# Altium schematic sheet MA-Power.SchDoc
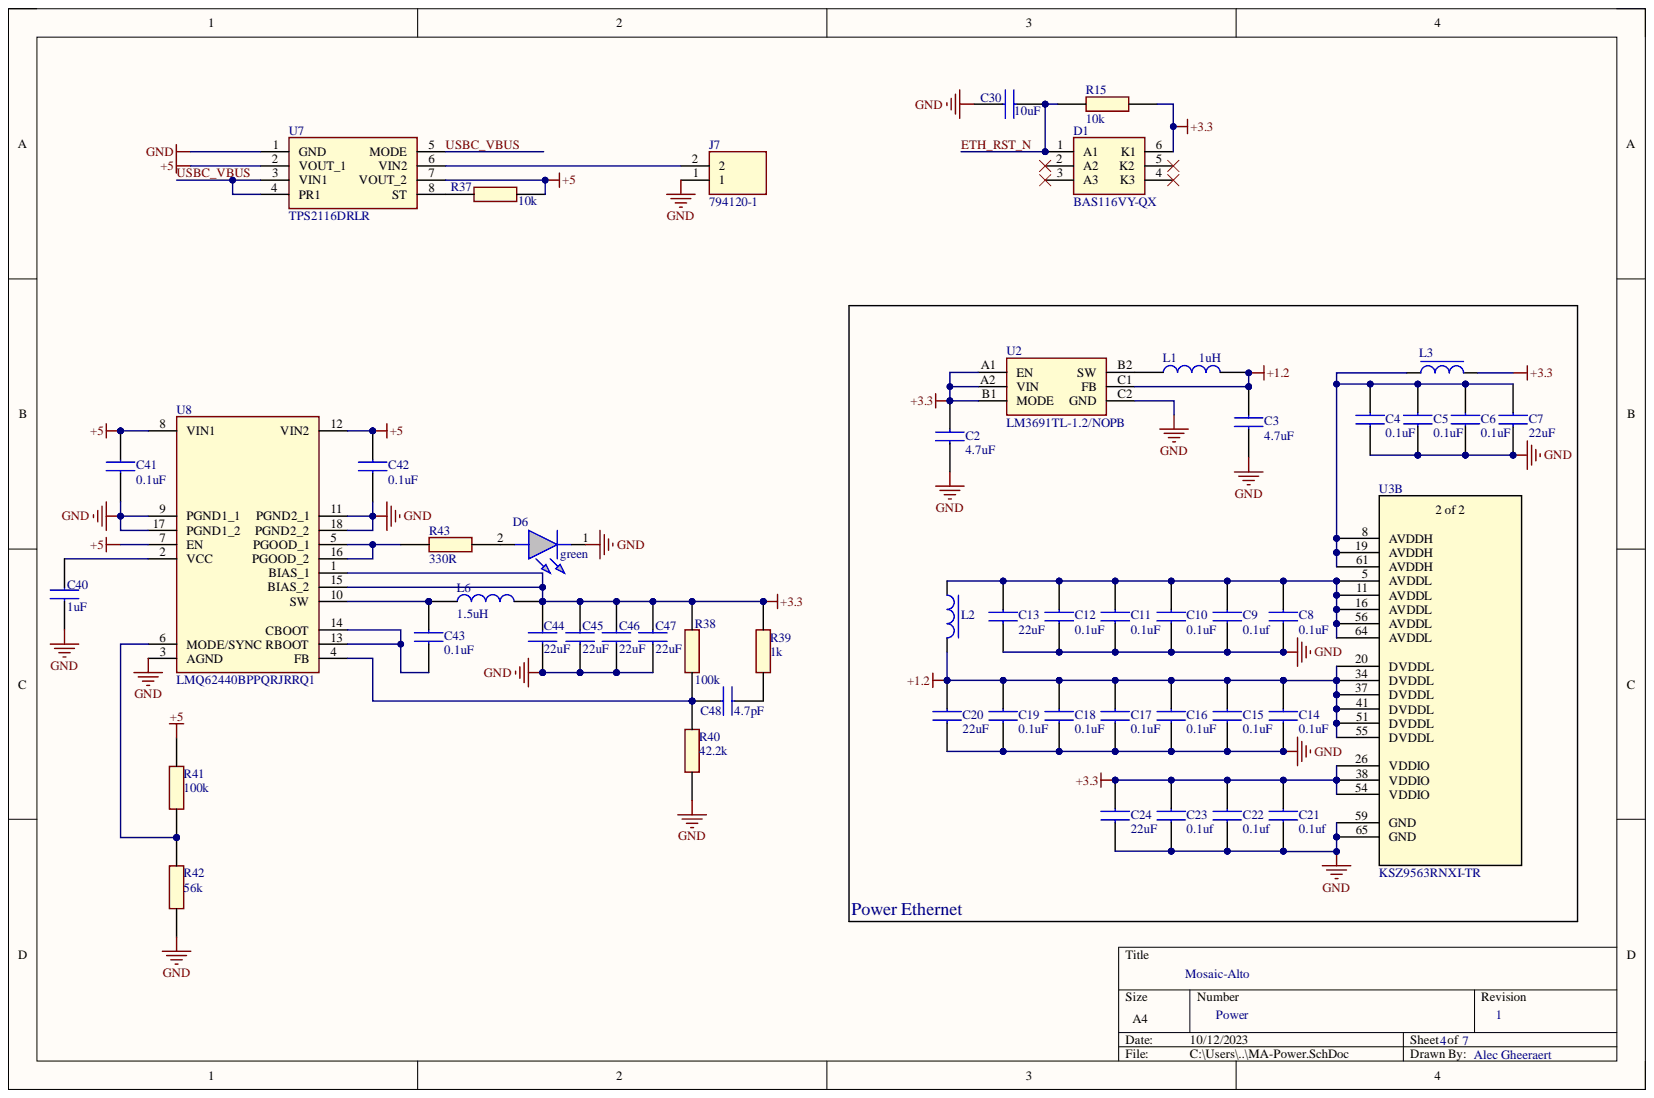
[diagram p0: MA-Power.SchDoc]

## Parts
C2  4.7uF  [CAPC1608X100N]
C3  4.7uF  [CAPC1608X100N]
C4  0.1uF  [CAPC1005X55N]
C5  0.1uF  [CAPC1005X55N]
C6  0.1uF  [CAPC1005X55N]
C7  22uF  [CAPC2012X145N]
C8  0.1uF  [CAPC1005X55N]
C9  0.1uf  [CAPC1005X55N]
C10  0.1uF  [CAPC1005X55N]
C11  0.1uF  [CAPC1005X55N]
C12  0.1uF  [CAPC1005X55N]
C13  22uF  [CAPC2012X145N]
C14  0.1uF  [CAPC1005X55N]
C15  0.1uF  [CAPC1005X55N]
C16  0.1uF  [CAPC1005X55N]
C17  0.1uF  [CAPC1005X55N]
C18  0.1uF  [CAPC1005X55N]
C19  0.1uF  [CAPC1005X55N]
C20  22uF  [CAPC2012X145N]
C21  0.1uf  [CAPC1005X55N]
C22  0.1uf  [CAPC1005X55N]
C23  0.1uf  [CAPC1005X55N]
C24  22uF  [CAPC2012X145N]
C30  10uF  [CAPC1608X100N]
C40  1uF  [CAPC1608X100N]
C41  0.1uF  [CAPC2012X145N]
C42  0.1uF  [CAPC2012X145N]
C43  0.1uF  [CAPC1005X55N]
C44  22uF  [CAPC2012X145N]
C45  22uF  [CAPC2012X145N]
C46  22uF  [CAPC2012X145N]
C47  22uF  [CAPC2012X145N]
C48  4.7pF  [CAPC1608X87N]
D1  BAS116VY-QX  [BAS116VYQX]
D6  green  [APT1608ZGCAMT]
J7  794120-1  [7941201]
L1  1uH  [INDC2016X100N]
L2  MPZ1608S121ATDH5  [BEADC1608X75N]  (value not drawn)
L3  MPZ1608S121ATDH5  [BEADC1608X75N]  (value not drawn)
L6  1.5uH  [INDC1005X55N]
R15  10k  [RESC1005X40N]
R37  10k  [RESC1005X40N]
R38  100k  [RESC1005X40N]
R39  1k  [RESC1005X40N]
R40  42.2k  [RESC1005X40N]
R41  100k  [RESC1005X40N]
R42  56k  [RESC1005X40N]
R43  330R  [RESC1005X40N]
U2  LM3691TL-1.2/NOPB  [BGA6C50P2X3_126X156X68]
U3  KSZ9563RNXI-TR  [VQFN64_NX_MCH]  (part B)
U7  TPS2116DRLR  [SOTFL50P160X60-8N]
U8  LMQ62440BPPQRJRRQ1  [LMQ62440BPPQRJRRQ1]

## Nets
+1.2: C3.1 C14.1 C15.1 C16.1 C17.1 C18.1 C19.1 C20.1 L1.2 L2.2 U2.C1(FB) U3.20(DVDDL) U3.34(DVDDL) U3.37(DVDDL) U3.41(DVDDL) U3.51(DVDDL) U3.55(DVDDL)
+3.3: C2.1 C21.1 C22.1 C23.1 C24.1 C44.1 C45.1 C46.1 C47.1 D1.6(K1) L3.2 L6.2 R15.1 R38.1 R39.2 U2.A1(EN) U2.A2(VIN) U2.B1(MODE) U3.26(VDDIO) U3.38(VDDIO) U3.54(VDDIO) U8.1(BIAS_1) U8.15(BIAS_2)
+5: C41.1 C42.1 R37.2 R41.2 U7.2(VOUT_1) U7.7(VOUT_2) U8.7(EN) U8.8(VIN1) U8.12(VIN2)
GND: C2.2 C3.2 C4.2 C5.2 C6.2 C7.2 C8.2 C9.2 C10.2 C11.2 C12.2 C13.2 C14.2 C15.2 C16.2 C17.2 C18.2 C19.2 C20.2 C21.2 C22.2 C23.2 C24.2 C30.2 C40.2 C41.2 C42.2 C44.2 C45.2 C46.2 C47.2 D6.1 J7.1 R40.2 R42.2 U2.C2(GND) U3.59(GND) U3.65(GND) U7.1(GND) U8.3(AGND) U8.9(PGND1_1) U8.11(PGND2_1) U8.17(PGND1_2) U8.18(PGND2_2)
ETH_RST_N: C30.1 D1.1(A1) R15.2
USBC_VBUS: U7.3(VIN1) U7.4(PR1) U7.5(MODE)
NetC4_1: C4.1 C5.1 C6.1 C7.1 L3.1 U3.8(AVDDH) U3.19(AVDDH) U3.61(AVDDH)
NetC8_1: C8.1 C9.1 C10.1 C11.1 C12.1 C13.1 L2.1 U3.5(AVDDL) U3.11(AVDDL) U3.16(AVDDL) U3.56(AVDDL) U3.64(AVDDL)
NetC40_1: C40.1 U8.2(VCC)
NetC43_1: C43.1 L6.1 U8.10(SW)
NetC43_2: C43.2 U8.13(RBOOT) U8.14(CBOOT)
NetC48_1: C48.1 R38.2 R40.1 U8.4(FB)
NetC48_2: C48.2 R39.1
NetD6_2: D6.2 R43.2
NetJ7_2: J7.2 U7.6(VIN2)
NetL1_1: L1.1 U2.B2(SW)
NetR37_1: R37.1 U7.8(ST)
NetR41_1: R41.1 R42.1 U8.6(MODE/SYNC)
NetR43_1: R43.1 U8.5(PGOOD_1) U8.16(PGOOD_2)

Not connected: D1 2(A2) 3(A3) 4(K3) 5(K2)

## Library data of the parts
C2 C3 = CMP-00054-2: 0603 4.7µF; Manufacturer: Walsin; Dielectric: X5R; Tolerance: 20%; Voltage Rating: 6.3V; Capacitance: 4.7µF; Component Kind: Standard; Max Operating Temperature: 85; Min Operating Temperature: -55; Package: 0603; Library Name: Ghrt
C4-C6 C8-C12 C14-C19 C21-C23 C43 = CMP-00051-3: 0402I 0.1µF; Manufacturer: Walsin; Capacitance: 100nF; Dielectric: X7R; Tolerance: 10%; Voltage Rating: 10V; Component Kind: Standard; Max Operating Temperature: 125; Min Operating Temperature: -55; Package: 0402; Library Name: Ghrt
C7 C13 C20 C24 C44-C47 = CMP-00053-2: 0805 22µF; Manufacturer: Walsin; Dielectric: X5R; Tolerance: 10%; Voltage Rating: 6.3V; Capacitance: 22µF; Component Kind: Standard; Max Operating Temperature: -55; Min Operating Temperature: 85; Package: 0805; Library Name: Ghrt
C30 = CMP-00062-1: 0603 10µF; Manufacturer: Walsin; Dielectric: X5R; Tolerance: 10%; Voltage Rating: 6.3V; Capacitance: 10µF; Component Kind: Standard; Max Operating Temperature: 85; Min Operating Temperature: -55; Package: 0603; Library Name: Ghrt
C40 = CMP-00064-1: 0603 1µF; Manufacturer: Walsin; Dielectric: X5R; Tolerance: 10%; Voltage Rating: 6.3V; Capacitance: 1µF; Component Kind: Standard; Max Operating Temperature: 85; Min Operating Temperature: -55; Package: 0603; Library Name: Ghrt
C41 C42 = CMP-00059-1: 0805I 0.1µF; Manufacturer: Walsin; Capacitance: 100nF; Dielectric: X7R; Qualification: AEC-Q200; Tolerance: 10%; Voltage Rating: 50V; Component Kind: Standard; Max Operating Temperature: -55; Min Operating Temperature: 125; Package: 0805; Library Name: Ghrt
C48 = CMP-00085-1: 0603 4.7pF; Manufacturer: Walsin; Dielectric: C0G; Tolerance: 5%; Voltage Rating: 16V; Capacitance: 4.7pF; Component Kind: Standard; Max Operating Temperature: 125; Min Operating Temperature: -55; Package: 0603; Library Name: Ghrt
D1 = CMP-00061-3: Triple Diode Array; Manufacturer: Nexperia; Forward Current: 180mA; Forward Voltage: 1.25V; Max Surge Current: 10A; Peak Reverse Voltage: 85V; Reverse Current: 5nA; Component Kind: Standard; Configuration: Triple; Package: TSSOP-6; Library Name: Ghrt
D6 = CMP-00079-2: 0603 Green LED; Colour: Green; Component Kind: Standard; Library Name: Ghrt
J7 = CMP-00082-2: Right Angle PCB Header; Component Kind: Standard; Library Name: Ghrt
L1 = CMP-00048-2: 1µH Inductor; Manufacturer: TDK; Max Current: 1.3A; Max Resistance: 90; Shielding: Yes; Tolerance: 20%; Component Kind: Standard; Inductance: 1µH; Max Operating Temperature: 125; Min Operating Temperature: -40; Package: 0806; Library Name: Ghrt
L2 L3 = CMP-00050-4: 0603I 120ohms Ferrite Bead; Manufacturer: TDK; Current Rating: 2A; Qualification: AEC-Q200; Resistance Rating: 120; Tolerance: 25%; Component Kind: Standard; Max Operating Temperature: 125; Min Operating Temperature: -55; Package: 0603; Library Name: Ghrt
L6 = CMP-00083-2: 1.5uH Inductor; Component Kind: Standard; Library Name: Ghrt
R15 R37 = CMP-00026-5: 0402I 10k; Manufacturer: Walsin; Power Rating: 62.5mW; Qualification: AEC-Q200; Tolerance: 1%; Voltage Rating: 50V; Component Kind: Standard; Max Operating Temperature: 155; Min Operating Temperature: -55; Package: 0402; Resistance: 10k; Library Name: Ghrt
R38 R41 = CMP-00029-4: 0402I 100k; Manufacturer: Walsin; Power Rating: 62.5mW; Qualification: AEC-Q200; Tolerance: 1%; Voltage Rating: 50V; Component Kind: Standard; Max Operating Temperature: 155; Min Operating Temperature: -55; Package: 0402; Resistance: 100k; Library Name: Ghrt
R39 = CMP-00032-3: 0402I 1k; Manufacturer: Walsin; Power Rating: 62.5mW; Qualification: AEC-Q200; Tolerance: 1%; Voltage Rating: 50V; Component Kind: Standard; Max Operating Temperature: 155; Min Operating Temperature: -55; Package: 0402; Resistance: 1k; Library Name: Ghrt
R40 = CMP-00084-1: 0402I 42.2k; Manufacturer: Walsin; Power Rating: 62.5mW; Qualification: AEC-Q200; Tolerance: 1%; Voltage Rating: 50V; Component Kind: Standard; Max Operating Temperature: 155; Min Operating Temperature: -55; Package: 0402; Resistance: 42.2k; Library Name: Ghrt
R42 = CMP-00075-1: 0402I 56k; Manufacturer: Walsin; Power Rating: 62.5mW; Qualification: AEC-Q200; Tolerance: 1%; Voltage Rating: 50V; Component Kind: Standard; Max Operating Temperature: 155; Min Operating Temperature: -55; Package: 0402; Resistance: 56k; Library Name: Ghrt
R43 = CMP-00028-4: 0402I 330; Manufacturer: Walsin; Power Rating: 62.5mW; Qualification: AEC-Q200; Resistance: 330; Tolerance: 1%; Voltage Rating: 50V; Component Kind: Standard; Max Operating Temperature: 155; Min Operating Temperature: -55; Package: 0402; Library Name: Ghrt
U2 = CMP-00047-3: 1.2V 1A Buck Converter; Manufacturer: Texas Instruments; Channels: 1; Frequency: 4MHz; Max Input Voltage: 5.5V; Min Input Voltage: 2.3V; Component Kind: Standard; Max Operating Temperature: 85; Max Output Voltage: 1.2V; Min Operating Temperature: -30; Min Output Voltage: 1.2V; Output Current: 1A; Package: DSBGA-6; Topology: Buck; Library Name: Ghrt
U3 = CMP-00057-8: 3-Port Gigabit Ethernet Switch; Manufacturer: Texas Instruments; Data Rate: 10/100/1000; Ethernet Type: 10/1000 Base-T, 100 Base-TX; Interfaces: I2C, MII, RGMII, RMII, SPI; Transceivers: 2; Component Kind: Standard; Max Operating Temperature: 85; Min Operating Temperature: -40; Package: VQFN-64; Library Name: Ghrt
U7 = CMP-00080-3: 2.5A Power Multiplexer; Manufacturer: Texas Instruments; Max Input Voltage: 5.5V; Min Input Voltage: 1.6V; Outputs: 1; Component Kind: Standard; Current Rating: 2.5A; Max Operating Temperature: 105; Min Operating Temperature: -40; Library Name: Ghrt
U8 = CMP-00081-2: 3.3V 4A Switching Voltage Regulator; Manufacturer: Texas Instruments; Channels: 1; Frequency: 2.1MHz; Max Input Voltage: 36V; Min Input Voltage: 3V; Topology: Buck; Component Kind: Standard; Max Operating Temperature: 150; Max Output Voltage: 3.3V; Min Operating Temperature: -40; Min Output Voltage: 3.3V; Output Current: 4A; Package: VQFN-14; Library Name: Ghrt
